# Altium schematic sheet Power_Amplifier.SchDoc
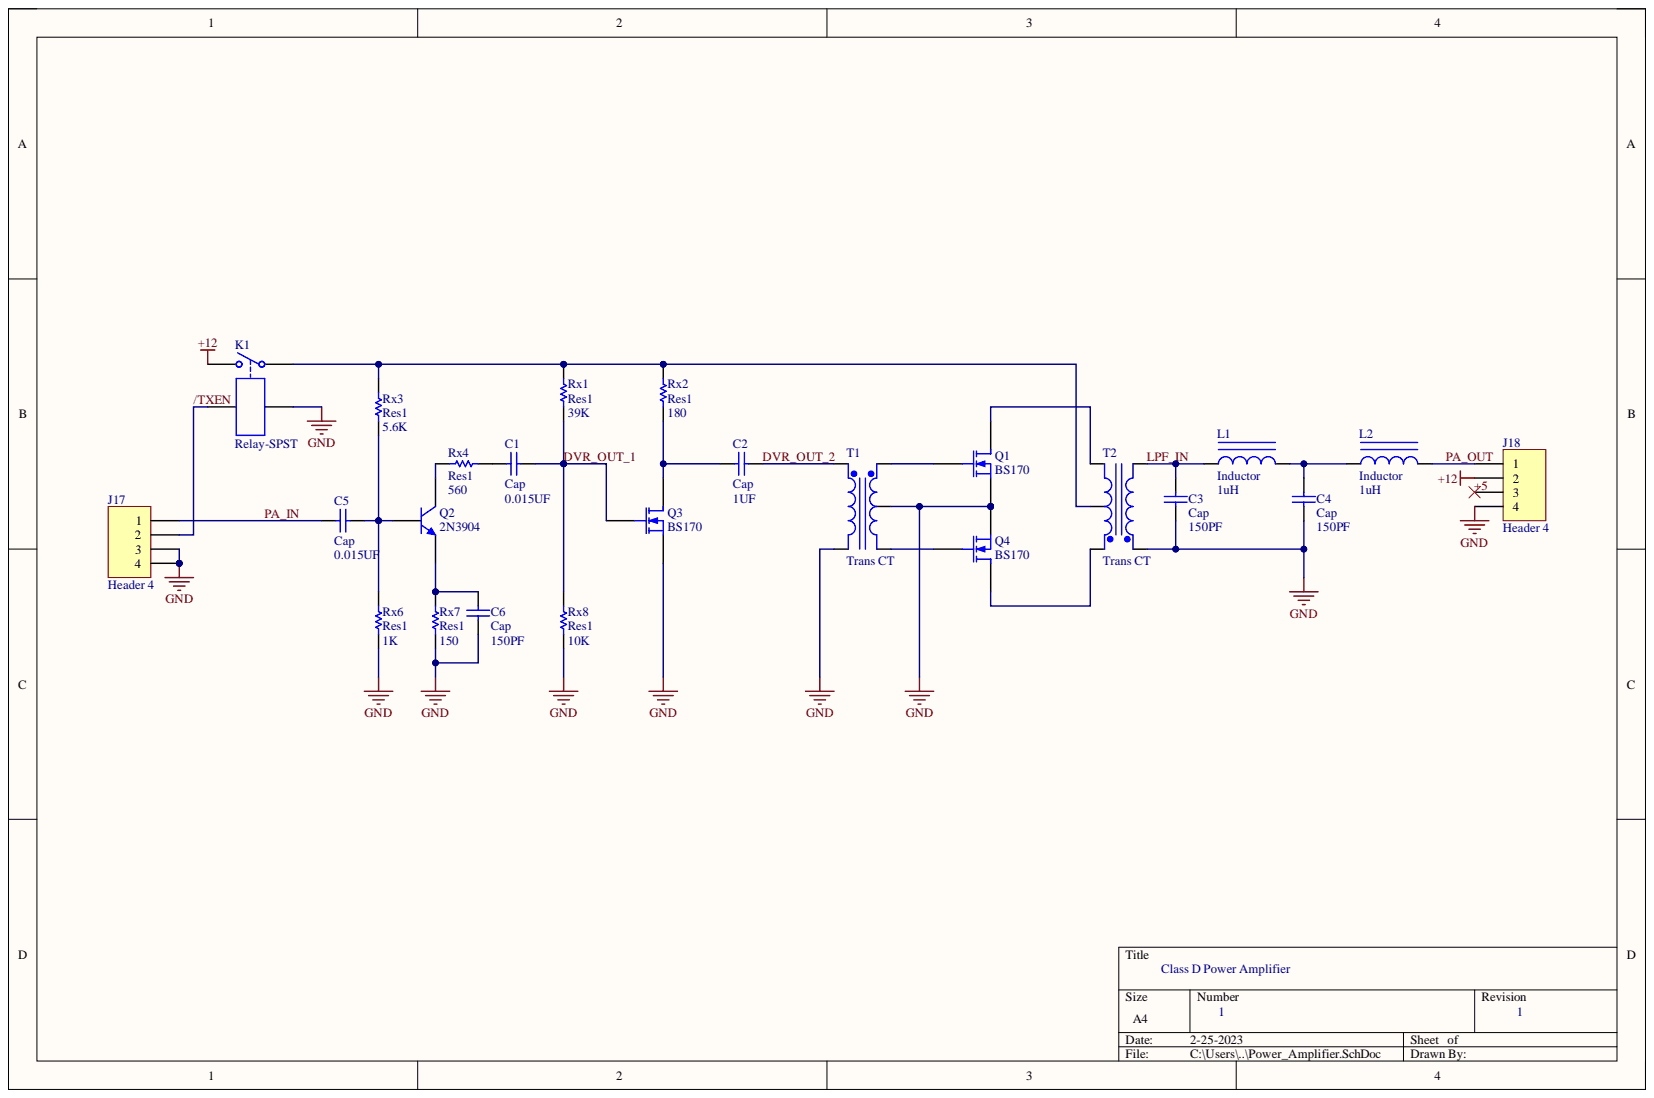
[diagram p0: Power_Amplifier.SchDoc]

## Parts
C1  Cap  value 0.015UF  [RADIAL-0.1]
C2  Cap  value 1UF  [RADIAL-0.1]
C3  Cap  value 150PF  [RADIAL-0.1]
C4  Cap  value 150PF  [RADIAL-0.1]
C5  Cap  value 0.015UF  [RADIAL-0.1]
C6  Cap  value 150PF  [RADIAL-0.1]
J17  Header 4  [HDR1X4]
J18  Header 4  [HDR1X4]
K1  Relay-SPST  [MODULE4]
L1  Inductor  value 1uH  [TORIND-T25]
L2  Inductor  value 1uH  [TORIND-T25]
Q1  BS170  [E3]
Q2  2N3904  [TO-92A]
Q3  BS170  [E3]
Q4  BS170  [E3]
Rx1  Res1  value 39K  [AXIAL-0.1-RES]
Rx2  Res1  value 180  [AXIAL-0.1-RES]
Rx3  Res1  value 5.6K  [AXIAL-0.1-RES]
Rx4  Res1  value 560  [AXIAL-0.1-RES]
Rx6  Res1  value 1K  [AXIAL-0.1-RES]
Rx7  Res1  value 150  [AXIAL-0.1-RES]
Rx8  Res1  value 10K  [AXIAL-0.1-RES]
T1  Trans CT  [BIFILAR-2402]
T2  Trans CT  [BIFILAR-2402]

## Nets
+12: J18.2 K1.2
GND: C3.1 C4.1 C6.1 J17.3 J17.4 J18.4 K1.3 Q1.3 Q3.3 Q4.3 Rx6.1 Rx7.1 Rx8.1 T1.2 T1.5 T2.4
+5: J18.3
/TXEN: J17.2 K1.4
DVR_OUT_1: C1.2 Q3.2 Rx1.1 Rx8.2
DVR_OUT_2: C2.2 T1.4
LPF_IN: C3.2 L1.1 T2.5
PA_IN: C5.1 J17.1
PA_OUT: J18.1 L2.2
NetC1_1: C1.1 Rx4.2
NetC2_1: C2.1 Q3.1 Rx2.1
NetC4_2: C4.2 L1.2 L2.1
NetC5_2: C5.2 Q2.2 Rx3.1 Rx6.2
NetC6_2: C6.2 Q2.1 Rx7.2
NetK1_1: K1.1 Rx1.2 Rx2.2 Rx3.2 T2.2
NetQ1_1: Q1.1 T2.3
NetQ1_2: Q1.2 T1.1
NetQ2_3: Q2.3 Rx4.1
NetQ4_1: Q4.1 T2.1
NetQ4_2: Q4.2 T1.3

## Library data of the parts
C1 C5 = CAP CER 0.015UF 50V X7R RADIAL: Capacitor; Manufacturer Part Number: C320C153K5R5TA; Voltage Rating: 50V; Component Kind: Standard; Designator: C?; LatestRevisionDate: 17-Jul-2002; LatestRevisionNote: Re-released for DXP Platform.; Library Name: ECE295-Capacitors-TH.IntLib; Published: 8-Jun-2000; Signal Integrity: Cap; Simulation: CAP; Publisher: Altium Limited
  C5: Value: 0.015UF
C2 = CAP ALUM 1UF 20% 100V RADIAL: Capacitor; Manufacturer Part Number: ESE105M100AC3EA; Voltage Rating: 100V; Component Kind: Standard; Designator: C?; LatestRevisionDate: 17-Jul-2002; LatestRevisionNote: Re-released for DXP Platform.; Library Name: ECE295-Capacitors-TH.IntLib; Published: 8-Jun-2000; Signal Integrity: Cap; Simulation: CAP; Publisher: Altium Limited
C3 C4 C6 = CAP CER 150PF 50V C0G/NP0 RADIAL: Capacitor; Manufacturer Part Number: K151J15C0GF53L2; Voltage Rating: 50V; Component Kind: Standard; Designator: C?; LatestRevisionDate: 17-Jul-2002; LatestRevisionNote: Re-released for DXP Platform.; Library Name: ECE295-Capacitors-TH.IntLib; Published: 8-Jun-2000; Signal Integrity: Cap; Simulation: CAP; Publisher: Altium Limited
J17 J18 = Header 4: Header, 4-Pin; Component Kind: Standard; LatestRevisionDate: 17-Jul-2002; LatestRevisionNote: Re-released for DXP Platform.; Library Name: Miscellaneous Connectors.IntLib; Signal Integrity: Connector; Publisher: Altium Limited
K1 = Relay-SPST: Single-Pole Single-Throw Relay; Component Kind: Standard; LatestRevisionDate: 17-Jul-2002; LatestRevisionNote: Re-released for DXP Platform.; Library Name: Miscellaneous Devices.IntLib; PackageReference: MODULE4; Published: 24-Mar-1999; Simulation: SPSTRELAY; Publisher: Altium Limited
L1 L2 = Toroidal inductor ferrite core: Component Kind: Standard; Description: Inductor; Designator: L?; LatestRevisionDate: 29-May-2009; LatestRevisionNote: IPC-7351 Footprint Added.; Library Name: ECE295-Other.IntLib; Published: 8-Jun-2000; Signal Integrity: Inductor; Simulation: INDUCTOR; Publisher: Altium Limited
Q1 Q3 Q4 = MOSFET-N: N-Channel MOSFET; Component Kind: Standard; LatestRevisionDate: 17-Jul-2002; LatestRevisionNote: Re-released for DXP Platform.; Library Name: Miscellaneous Devices.IntLib; PackageDescription: TO, Flat Index; 3 In-Line, Axial Leads; Body Dia. 4.6mm; Lead Dia. 0.5 mm (max); PackageReference: E3; PackageVersion: 1995/1996; Published: 8-Jun-2000; Simulation: NMOS; Publisher: Altium Limited
Q2 = 2N3904: NPN General Purpose Amplifier; Component Kind: Standard; ComponentLink1Description: Manufacturer Link; ComponentLink1URL: http://www.fairchildsemi.com/; ComponentLink2Description: Datasheet; ComponentLink2URL: http://www.fairchildsemi.com/ds/2N%2F2N3904.pdf; DatasheetVersion: 1997; LatestRevisionDate: 15-Jan-2003; LatestRevisionNote: PCB Footprint 'BCY-W3/D4.7' replaced; Library Name: Miscellaneous Devices.IntLib; PackageDescription: TO, Flat Index; 3 In-Line, Axial Leads; Body Dia. 4.5mm; Leads 0.51 x 0.48 mm (max); PackageReference: TO-92A; PackageVersion: Sep-1998; Published: 8-Jun-2000; Signal Integrity: 2N3904; Simulation: 2N3904; Publisher: Altium Limited
Rx1 = RES 39K OHM 5% 1/4W AXIAL: Resistor; Manufacturer Part Number: CFR-25JB-52-39K; Power Rating: 1/4W; Tolerance: 0.05; Component Kind: Standard; Designator: R?; LatestRevisionDate: 17-Jul-2002; LatestRevisionNote: Re-released for DXP Platform.; Library Name: ECE295-Resistors-TH.IntLib; Published: 8-Jun-2000; Signal Integrity: Res; Simulation: RESISTOR; Publisher: Altium Limited
Rx2 = RES 180 OHM 5% 1/4W AXIAL: Resistor; Manufacturer Part Number: CFR-25JB-52-180R; Power Rating: 1/4W; Tolerance: 0.05; Component Kind: Standard; Designator: R?; LatestRevisionDate: 17-Jul-2002; LatestRevisionNote: Re-released for DXP Platform.; Library Name: ECE295-Resistors-TH.IntLib; Published: 8-Jun-2000; Signal Integrity: Res; Simulation: RESISTOR; Publisher: Altium Limited
Rx3 = RES 5.6K OHM 5% 1/4W AXIAL: Resistor; Manufacturer Part Number: CFR-25JB-52-5K6; Power Rating: 1/4W; Tolerance: 0.05; Component Kind: Standard; Designator: R?; LatestRevisionDate: 17-Jul-2002; LatestRevisionNote: Re-released for DXP Platform.; Library Name: ECE295-Resistors-TH.IntLib; Published: 8-Jun-2000; Signal Integrity: Res; Simulation: RESISTOR; Publisher: Altium Limited
Rx4 = RES 560 OHM 5% 1/4W AXIAL: Resistor; Manufacturer Part Number: CFR-25JB-52-560R; Power Rating: 1/4W; Tolerance: 0.05; Value: 560; Component Kind: Standard; Designator: R?; LatestRevisionDate: 17-Jul-2002; LatestRevisionNote: Re-released for DXP Platform.; Library Name: ECE295-Resistors-TH.IntLib; Published: 8-Jun-2000; Signal Integrity: Res; Simulation: RESISTOR; Publisher: Altium Limited
Rx6 = RES 1K OHM 5% 1/4W AXIAL: Resistor; Manufacturer Part Number: CFR-25JB-52-1K; Power Rating: 1/4W; Tolerance: 0.05; Component Kind: Standard; Designator: R?; LatestRevisionDate: 17-Jul-2002; LatestRevisionNote: Re-released for DXP Platform.; Library Name: ECE295-Resistors-TH.IntLib; Published: 8-Jun-2000; Signal Integrity: Res; Simulation: RESISTOR; Publisher: Altium Limited
Rx7 = RES 150 OHM 5% 1/4W AXIAL: Resistor; Manufacturer Part Number: CFR-25JB-52-150R; Power Rating: 1/4W; Tolerance: 0.05; Component Kind: Standard; Designator: R?; LatestRevisionDate: 17-Jul-2002; LatestRevisionNote: Re-released for DXP Platform.; Library Name: ECE295-Resistors-TH.IntLib; Published: 8-Jun-2000; Signal Integrity: Res; Simulation: RESISTOR; Publisher: Altium Limited
Rx8 = RES 10K OHM 5% 1/4W AXIAL: Resistor; Manufacturer Part Number: CFR-25JB-52-10K; Power Rating: 1/4W; Tolerance: 0.05; Component Kind: Standard; Designator: R?; LatestRevisionDate: 17-Jul-2002; LatestRevisionNote: Re-released for DXP Platform.; Library Name: ECE295-Resistors-TH.IntLib; Published: 8-Jun-2000; Signal Integrity: Res; Simulation: RESISTOR; Publisher: Altium Limited
T1 T2 = Centre-tapped transformer wound on a binocular core: Center-Tapped Transformer (Coupled Inductor Model); Component Kind: Standard; Designator: T?; LatestRevisionDate: 17-Jul-2002; LatestRevisionNote: Re-released for DXP Platform.; Library Name: ECE295-Other.IntLib; Published: 8-Jun-2000; Simulation: TRANSFORMER; Publisher: Altium Limited
